# Altium schematic sheet CONNECTOR.SchDoc
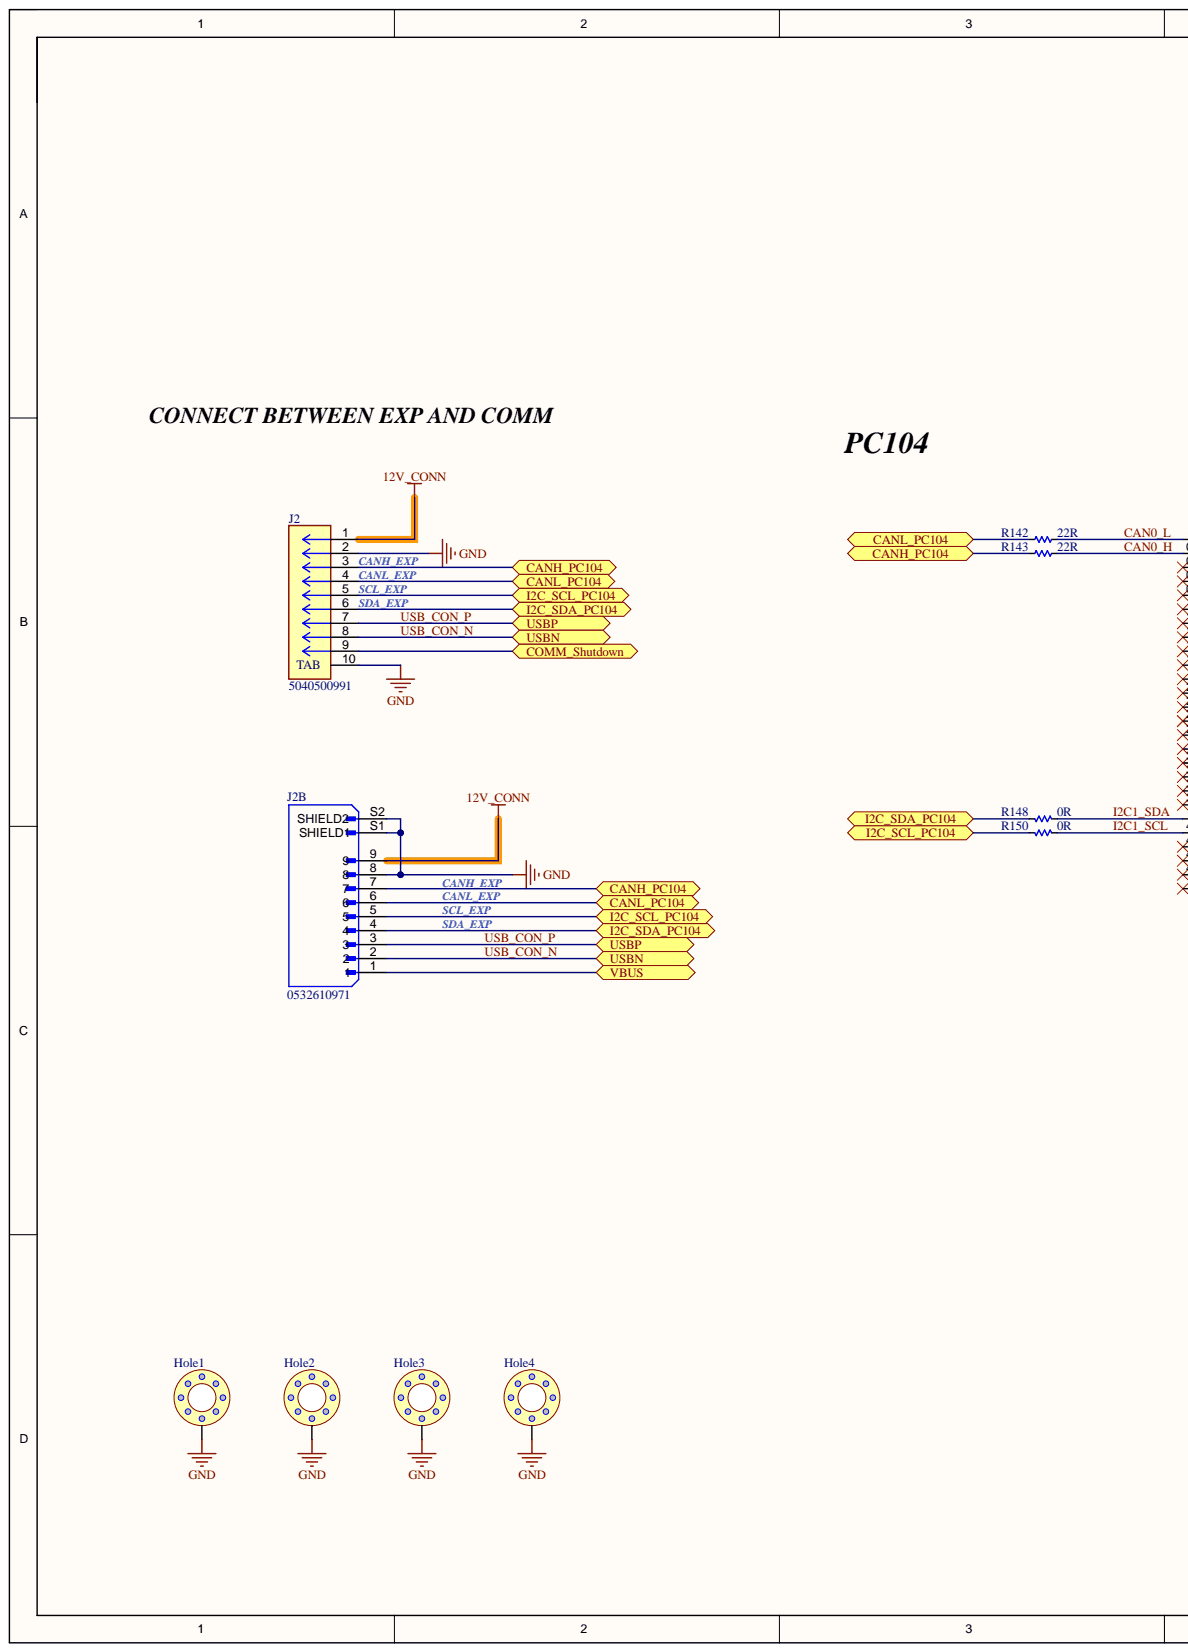
[diagram p0: CONNECTOR.SchDoc, left]
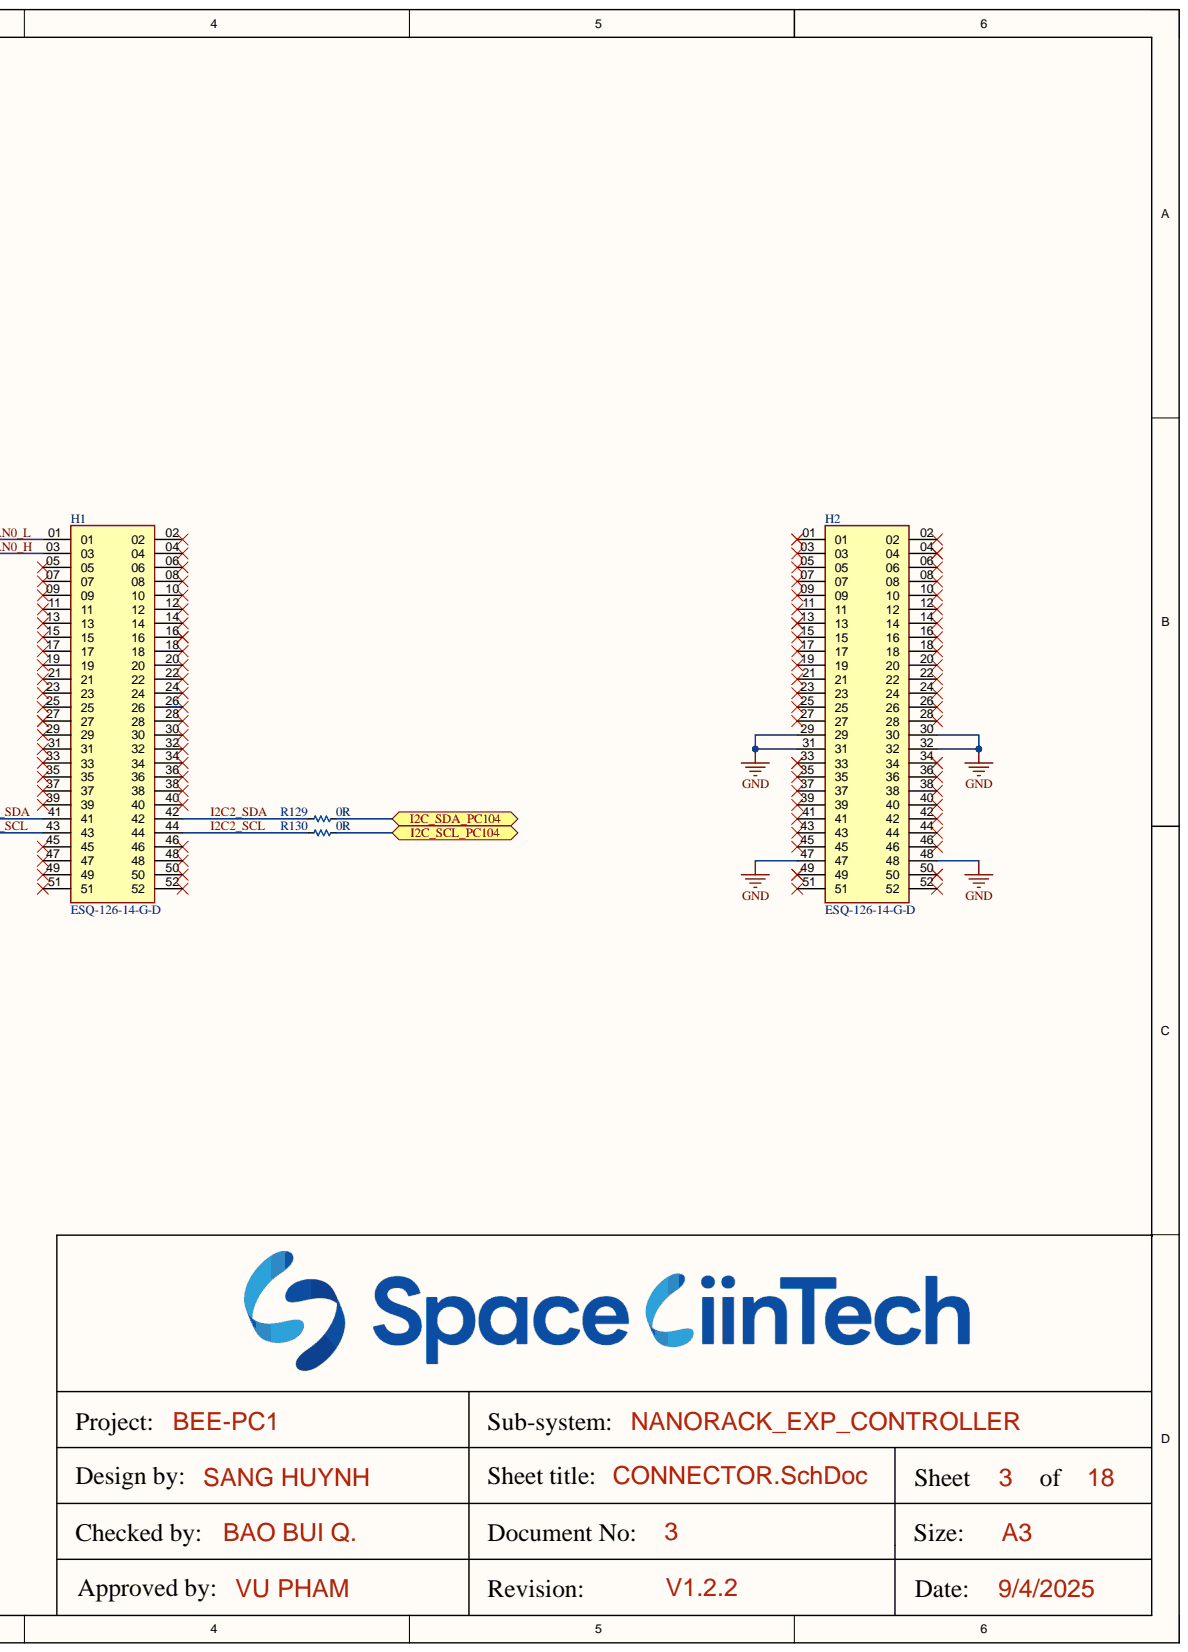
[diagram p1: CONNECTOR.SchDoc, right]

## Parts
H1  ESQ-126-14-G-D  [SAMTEC_ESQ-126-14-G-D]
H2  ESQ-126-14-G-D  [SAMTEC_ESQ-126-14-G-D]
Hole1  hole_space  [HOLE_SPACE]  (value not drawn)
Hole2  hole_space  [HOLE_SPACE]  (value not drawn)
Hole3  hole_space  [HOLE_SPACE]  (value not drawn)
Hole4  hole_space  [HOLE_SPACE]  (value not drawn)
J2  5040500991  [5040500991]
J2B  0532610971  [0532610971]
R129  0R  [RES_C_1608X60]
R130  0R  [RES_C_1608X60]
R142  22R  [RES_C_1608X60]
R143  22R  [RES_C_1608X60]
R148  0R  [RES_C_1608X60]
R150  0R  [RES_C_1608X60]

## Ports
CANH_PC104, CANL_PC104, COMM_Shutdown, I2C_SCL_PC104, I2C_SDA_PC104, USBN, USBP, VBUS

## Nets
12V_CONN: J2.1 J2B.9
GND: H2.29 H2.30 H2.31 H2.32 H2.47 H2.48 Hole1.1 Hole2.1 Hole3.1 Hole4.1 J2.2 J2.10(TAB) J2B.8 J2B.S1(SHIELD1) J2B.S2(SHIELD2)
CAN0_H: H1.03 R143.2
CAN0_L: H1.01 R142.2
CANH_PC104: J2.3 J2B.7 R143.1 ; port CANH_PC104
CANL_PC104: J2.4 J2B.6 R142.1 ; port CANL_PC104
COMM_Shutdown: J2.9 ; port COMM_Shutdown
I2C1_SCL: H1.43 R150.1
I2C1_SDA: H1.41 R148.1
I2C2_SCL: H1.44 R130.1
I2C2_SDA: H1.42 R129.1
I2C_SCL_PC104: J2.5 J2B.5 R130.2 R150.2 ; port I2C_SCL_PC104
I2C_SDA_PC104: J2.6 J2B.4 R129.2 R148.2 ; port I2C_SDA_PC104
USB_CON_N: J2.8 J2B.2 ; port USBN
USB_CON_P: J2.7 J2B.3 ; port USBP
VBUS: J2B.1 ; port VBUS

Not connected: H1 02 04 05 06 07 08 09 10 11 12 13 14 15 16 17 18 19 20 21 22 23 24 25 26 27 28 29 30 31 32 33 34 35 36 37 38 39 40 45 46 47 48 49 50 51 52; H2 01 02 03 04 05 06 07 08 09 10 11 12 13 14 15 16 17 18 19 20 21 22 23 24 25 26 27 28 33 34 35 36 37 38 39 40 41 42 43 44 45 46 49 50 51 52

## Library data of the parts
H1 H2 = ESQ-126-14-G-D: MF: Samtec; Availability: Unavailable; Component Kind: Standard; MP: ESQ-126-14-G-D; Package: None; Price: None; Library Name: COMM_SCHLIB.SchLib
J2 = 5040500991: Connector Header Surface Mount, Right Angle 9 position 0.059" (1.50mm); Component Kind: Standard; Library Name: COMM_SCHLIB.SchLib
J2B = CONN_SMD_09: CONN HEADER SMD R/A 9POS 1.25MM; Component Kind: Standard; Library Name: COMM_SCHLIB.SchLib
R129 R130 R142 R143 R148 R150 = _RES_B_2: RES; Component Kind: Standard; Library Name: LABLIB.SchLib
Hole1-Hole4 = hole_space: Component Kind: Standard; Library Name: COMM_SCHLIB.SchLib
